# Altium schematic sheet Bat_Detector_Sheet.SchDoc
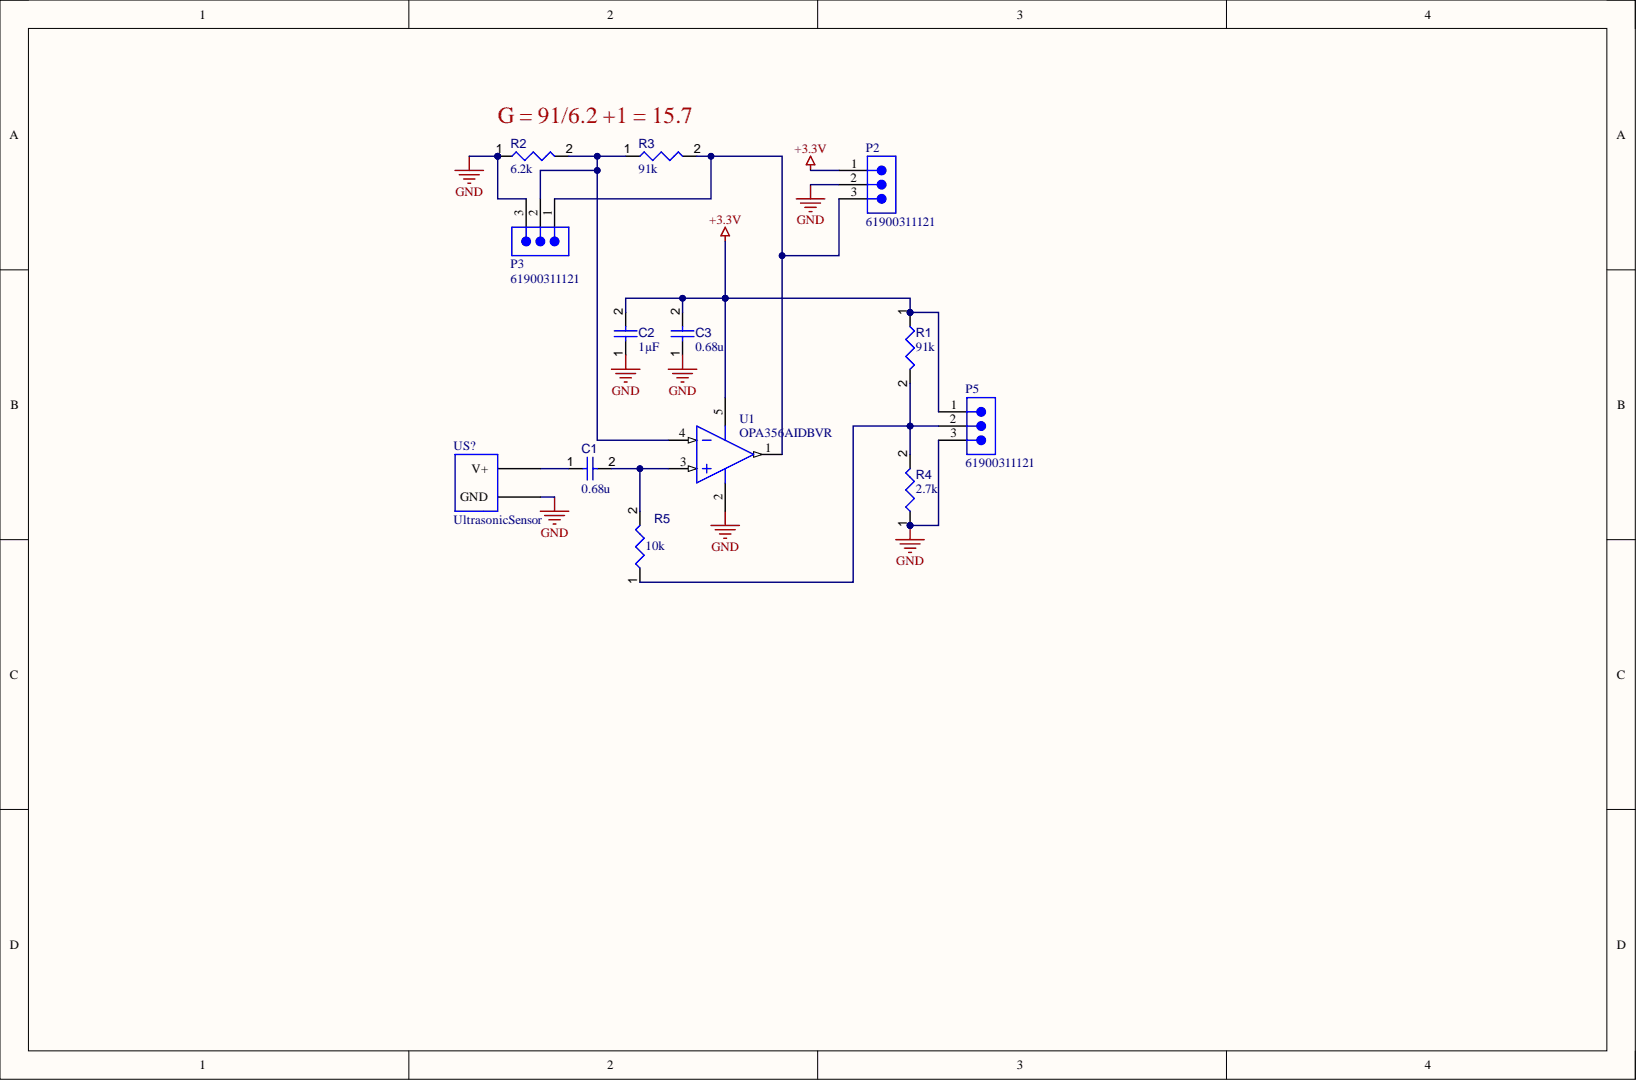
[diagram p0: Bat_Detector_Sheet.SchDoc]

## Parts
C1  value 0.68u  [CAPC0805(2012)145_L]  (hidden comment: Capacitor 4.7µF +/-40% 25V 0805)
C2  value 1µF  [CAPC0805(2012)145_L]  (hidden comment: Capacitor 4.7µF +/-40% 25V 0805)
C3  value 0.68u  [CAPC0805(2012)145_L]  (hidden comment: Capacitor 4.7µF +/-40% 25V 0805)
P2  61900311121  [61900311121]
P3  61900311121  [61900311121]
P5  61900311121  [61900311121]
R1  value 91k  [RESC0805(2012)_L]  (hidden comment: Resistor 1K +/-5% 0805 125 mW)
R2  value 6.2k  [RESC0805(2012)_L]  (hidden comment: Resistor 1K +/-5% 0805 125 mW)
R3  value 91k  [RESC0805(2012)_L]  (hidden comment: Resistor 1K +/-5% 0805 125 mW)
R4  value 2.7k  [RESC0805(2012)_L]  (hidden comment: Resistor 1K +/-5% 0805 125 mW)
R5  value 10k  [RESC0805(2012)_L]  (hidden comment: Resistor 1K +/-5% 0805 125 mW)
U1  OPA356AIDBVR  [DBV0005A_M]
US?  UltrasonicSensor  [RESMELF110150N]

## Nets
+3.3V: C2.2 C3.2 P2.1 P5.1 R1.1 U1.5
GND: C2.1 C3.1 P2.2 P3.3 P5.3 R2.1 R4.1 U1.2 US?.1
NetC1_1: C1.1 US?.0
NetC1_2: C1.2 R5.2 U1.3
NetP2_3: P2.3 P3.1 R3.2 U1.1
NetP3_2: P3.2 R2.2 R3.1 U1.4
NetP5_2: P5.2 R1.2 R4.2 R5.1

## Library data of the parts
C1-C3 = CMP-001-00080-4: Chip Capacitor, 4.7µF +/-40%, 25V, 0805, Thickness 1.45 mm; Tolerance: 20%; Case/Package: 0805; Component Kind: Standard; Max Operating Temperature: 85°C; Min Operating Temperature: -55°C; Mounting Technology: SMT; Pins: 2; Voltage Rating: 25V; Library Name: Andrii Bilyi
P2 P3 P5 = CMP-1502-00585-2: NexarPartId: 18818222; Connector Type: Male Pin; Contact Resistance: 20mΩ; Current Rating: 3A; Gender: Male; Insulation Resistance: 1GΩ; Number of Contacts: 3; Operating Supply Voltage: 250V; Pitch: 2.54mm; Color: Beige; Component Kind: Standard; Contact Gender: Male; Contact Material: Copper; Contact Mating Length: 7.493mm; Contact Plating: Tin; Fastening Type: Friction; Flammability Rating: UL94 V-0; Height: 12.5mm; Housing Material: Nylon; Lead Pitch: 2.54mm; Length: 7.62mm; Library Name: Altium Content Vault; Max Operating Temperature: 105°C; Min Operating Temperature: -40°C; Mount: Through Hole; Number of Pins: 3; Number of Positions: 3; Number of Rows: 1; Orientation: Vertical; Packaging: Bulk; REACH SVHC: Unknown; RoHS: Compliant; Termination: Through Hole; Voltage Rating: 250V; Width: 7.66mm
R1-R5 = CMP-009-00118-3: Chip Resistor, 1 kOhms, +/-5%, 125 mW, -55 to 155 degC, 0805; Power: 125mW; Tolerance: 5%; Case/Package: 0805; Component Kind: Standard; Max Operating Temperature: 155°C; Min Operating Temperature: -55°C; Pins: 2; Library Name: Andrii Bilyi
U1 = CMP-0016-00070-2: 200 MHz GBW, CMOS Single Operational Amplifier, 2.5 to 5.5 V, -40 to 125 degC, 5-pin SOT23 (DBV5), Green (RoHS & no Sb/Br); Manufacturer: Texas Instruments; 2nd Harmonic(dBc): 81; 3rd Harmonic(dBc): 93; @ MHz: 1; BW @ Acl(MHz): 450; Diff Gain(%): 0.02; Diff Phase(deg): 0.05; Io(Typ)(mA): 100; Iq per channel(Max)(mA): 14; Rail-Rail: Out; Settling Time (0.1%)(Typ)(ns): 30; Slew Rate(Typ)(V/us): 360; Sub Family: High Output Current Amplifier (>=50mA), High Speed Amplifier (>=50MHz), Low Supply Voltage Amplifier (<=2.7V); THD (Fc is 1 MHz)(Typ)(dB): -79; Vio(Max)(mV): 9; Vn at Flatband(Typ)(nV/rtHz): 5.8; Vs(Max)(V): 5.5; Vs(Min)(V): 2.5; Acl, min stable gain(V/V): 1; Code_JEDEC: MO-178; Component Kind: Standard; ComponentLink1Description: Manufacturer URL; ComponentLink1URL: http://www.ti.com/; ComponentLink2Description: Datasheet; ComponentLink2URL: http://www.ti.com/general/docs/lit/getliterature.tsp?genericPartNumber=OPA356&fileType=pdf; ComponentLink3Description: Package Specification; ComponentLink3URL: http://www.ti.com/litv/pdf/mpds018i; DatasheetVersion: SBOS212A; Eco-Plan: Green (RoHS & no Sb/Br); Iib(Max)(nA): 0.05; Library Name: Altium Content Vault; Mounting Technology: Surface Mount; Number of Channels: 1; PackageDescription: 5-Pin Plastic Small Outline, Body 1.6 x 2.9 mm, Pitch 0.95 mm; PackageReference: DBV0005A; PackageVersion: 4073253-4/K, 03/2006; PartNumber: OPA356AIDBVR
US? = UltrasonicSensor: A simple ultrasonic sensor HC-SR04; Component Kind: Standard; Library Name: UltrasonicSensor.SchLib
